# Altium schematic sheet 36V_Supply.SchDoc(36V_Supply)
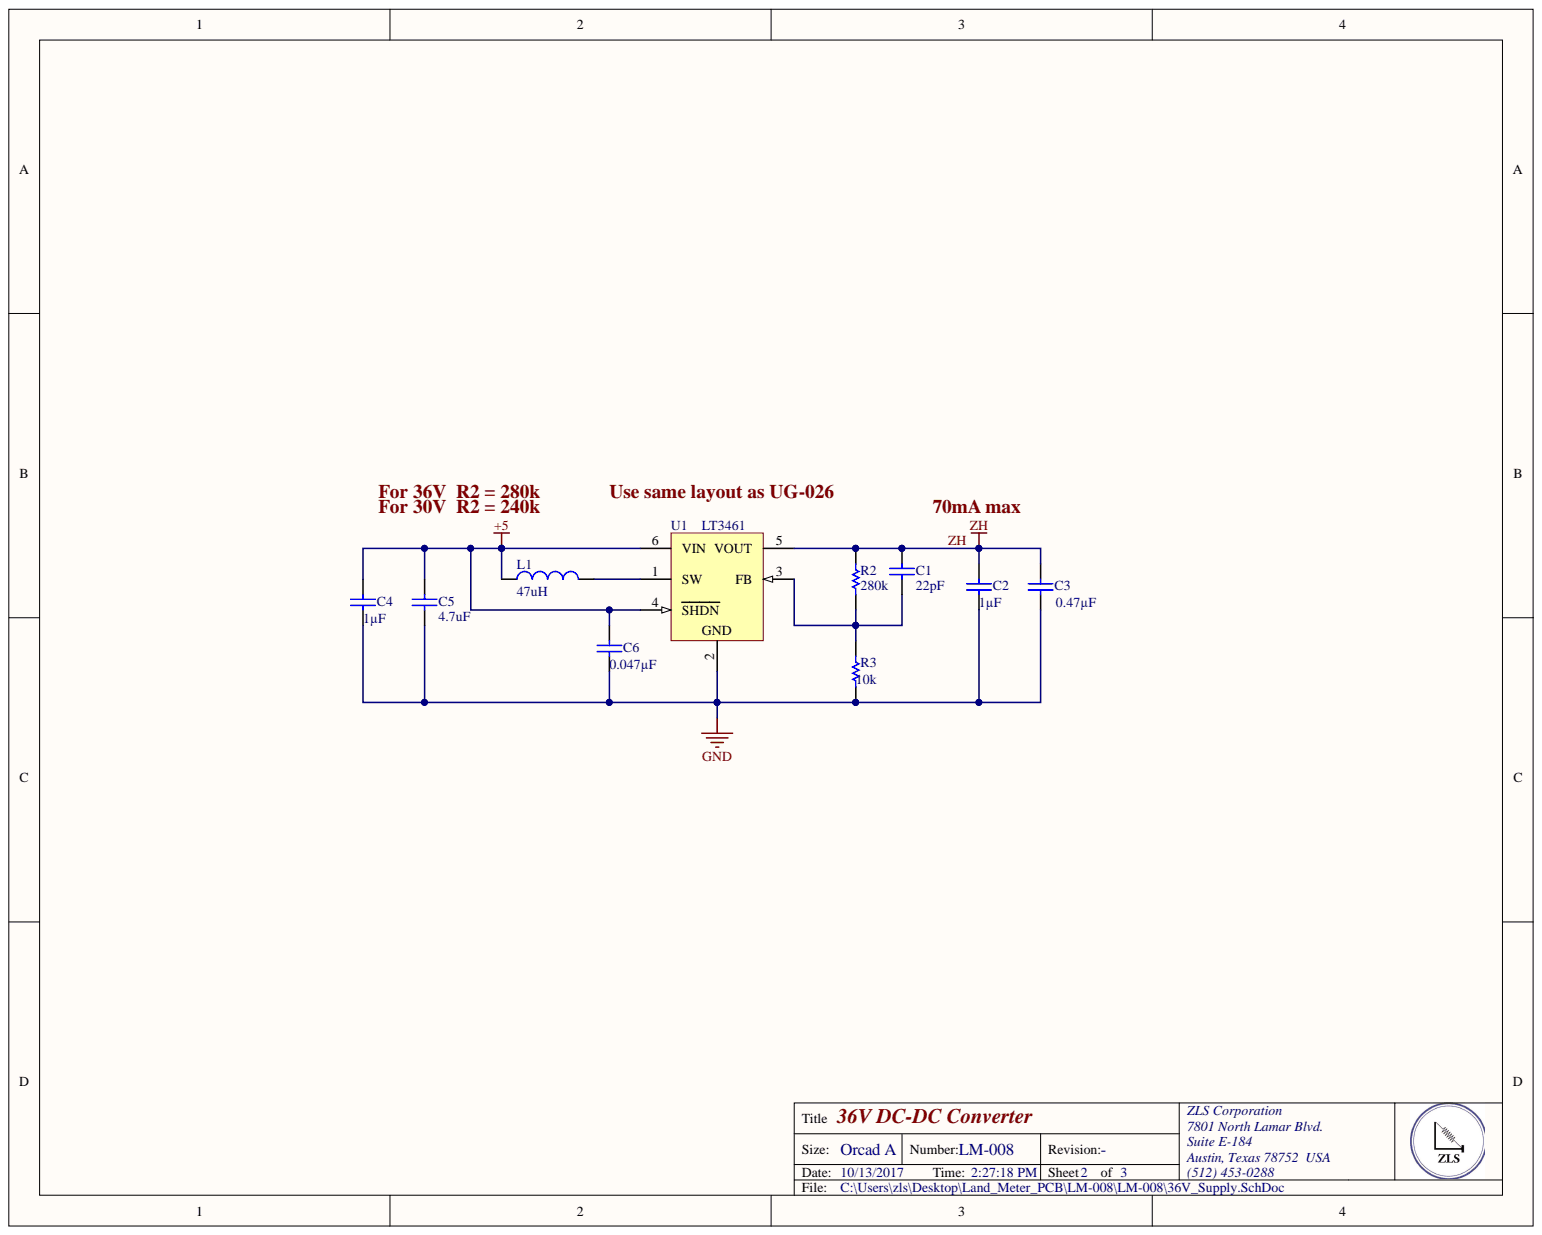
[diagram p0: 36V_Supply.SchDoc]

## Parts
C1  22pF  [CAPC1206(3216)170_L]
C2  Capacitance: 1µF  [CAPC1210(3225)100_N]  (hidden comment: CAP CER 1UF 50V X7R 1210)
C3  Capacitance: 0.47µF  [CAPC1206(3216)190_N]  (hidden comment: CAP CER 0.47UF 50V X7R 1206)
C4  Capacitance: 1µF  [CAPC0805(2012)145_N]  (hidden comment: CAP CER 1UF 16V X7R 0805)
C5  4.7uF  [CAPC0805(2012)145_M]
C6  Capacitance: 0.047µF  [CAPC0805(2012)145_N]  (hidden comment: CAP CER 0.047UF 16V X7R 0603)
L1  47uH  [C1210]
R2  Resistance (Ohms): 280k  [RESC0805(2012)_M]  (hidden comment: RES SMD 280K OHM 1% 1/8W 0805)
R3  Resistance (Ohms): 10k  [RESC0805(2012)_M]  (hidden comment: RES SMD 10K OHM 1% 1/8W 0805)
U1  LT3461  [LT-S6-6-TSOT-23_N]

## Nets
+5: C4.2 C5.2 C6.2 L1.1 U1.4(SHDN) U1.6(VIN)
GND: C2.1 C3.1 C4.1 C5.1 C6.1 R3.2 U1.2
ZH: C1.2 C2.2 C3.2 R2.1 U1.5(VOUT)
NetC1_1: C1.1 R2.2 R3.1 U1.3(FB)
NetL1_2: L1.2 U1.1(SW)

## Library data of the parts
C1 = CMP-1037-04573-1: CAP CER 22PF 50V C0G/NP0 1206; Manufacturer Part Number: C1206C220K5GACTU; Manufacturer: KEMET; Tolerance: ±10%; Temperature Coefficient: C0G, NP0; Voltage - Rated: 50V; Thickness (Max): 0.035" (0.88mm); Supplier Part Number 1: 399-8165-1-ND; Supplier 1: Digi-Key; Stock 1: 35613; Size / Dimension: 0.126" L x 0.063" W (3.20mm x 1.60mm); RoHS: RoHS Compliant; Ratings: -; Pricing 1: 1=0.18, 10=0.128, 100=0.06, 500=0.04208, 1000=0.03483 (USD); Part Status: Active; Packaging: Cut Tape (CT); Package / Case: 1206 (3216 Metric); Operating Temperature: -55°C ~ 125°C; Mounting Type: Surface Mount, MLCC; Library Name: Altium Content Vault; Lead Style: -; Lead Spacing: -; Height - Seated (Max): -; Features: Low ESL; Failure Rate: -; ComponentLink1URL: http://www.kemet.com/docfinder?Partnumber=C1206C220K2GACTU; ComponentLink1Description: http://www.kemet.com/docfinder?Partnumber=C1206C220K2GACTU; Component Kind: Standard; Category: Capacitors; Applications: General Purpose
C2 = CMP-1038-01123-1: Manufacturer Part Number: UMK325B7105KH-T; Manufacturer: Taiyo Yuden; Tolerance: ±10%; Voltage - Rated: 50V; Thickness (Max): 0.063" (1.60mm); Temperature Coefficient: X7R; Supplier Part Number 1: 587-1366-1-ND; Supplier 1: Digi-Key; Stock 1: 23864; Size / Dimension: 0.126" L x 0.098" W (3.20mm x 2.50mm); RoHS: RoHS Compliant; Ratings: -; Pricing 1: 1=0.36, 10=0.247, 100=0.1398, 500=0.0987, 1000=0.08637 (USD); Part Status: Active; Packaging: Cut Tape (CT); Package / Case: 1210 (3225 Metric); Operating Temperature: -55°C ~ 125°C; Mounting Type: Surface Mount, MLCC; Library Name: Altium Content Vault; Lead Style: -; Lead Spacing: -; Height - Seated (Max): -; Features: -; Description: CAP CER 1UF 50V X7R 1210; ComponentLink1URL: http://www.yuden.co.jp/productdata/catalog/en/mlcc_all_e.pdf; ComponentLink1Description: http://www.yuden.co.jp/productdata/catalog/en/mlcc_all_e.pdf; Component Kind: Standard; Category: Capacitors; Applications: General Purpose
C3 = CMP-1037-04570-1: Manufacturer Part Number: UMK316B7474KL-T; Manufacturer: Taiyo Yuden; Tolerance: ±10%; Voltage - Rated: 50V; Thickness (Max): 0.071" (1.80mm); Temperature Coefficient: X7R; Supplier Part Number 1: 587-1325-1-ND; Supplier 1: Digi-Key; Stock 1: 8658; Size / Dimension: 0.126" L x 0.063" W (3.20mm x 1.60mm); RoHS: RoHS Compliant; Ratings: -; Pricing 1: 1=0.3, 10=0.203, 100=0.115, 500=0.08114, 1000=0.071 (USD); Part Status: Active; Packaging: Cut Tape (CT); Package / Case: 1206 (3216 Metric); Operating Temperature: -55°C ~ 125°C; Mounting Type: Surface Mount, MLCC; Library Name: Altium Content Vault; Lead Style: -; Lead Spacing: -; Height - Seated (Max): -; Features: -; Description: CAP CER 0.47UF 50V X7R 1206; ComponentLink1URL: http://www.yuden.co.jp/productdata/catalog/en/mlcc_all_e.pdf; ComponentLink1Description: http://www.yuden.co.jp/productdata/catalog/en/mlcc_all_e.pdf; Component Kind: Standard; Category: Capacitors; Applications: General Purpose
C4 = CMP-1036-04795-1: Manufacturer Part Number: EMK212B7105KG-T; Manufacturer: Taiyo Yuden; Tolerance: ±10%; Voltage - Rated: 16V; Thickness (Max): 0.053" (1.35mm); Temperature Coefficient: X7R; Supplier Part Number 1: 587-1283-1-ND; Supplier 1: Digi-Key; Stock 1: 41495; Size / Dimension: 0.079" L x 0.049" W (2.00mm x 1.25mm); RoHS: RoHS Compliant; Ratings: -; Pricing 1: 1=0.13, 10=0.089, 100=0.042, 1000=0.02439 (USD); Part Status: Active; Packaging: Cut Tape (CT); Package / Case: 0805 (2012 Metric); Operating Temperature: -55°C ~ 125°C; Mounting Type: Surface Mount, MLCC; Library Name: Altium Content Vault; Lead Style: -; Lead Spacing: -; Height - Seated (Max): -; Features: -; Description: CAP CER 1UF 16V X7R 0805; ComponentLink1URL: http://ds.yuden.co.jp/TYCOMPAS/ut/detail.do?productNo=EMK212B7105KG-T&fileName=EMK212B7105KG-T_SS&mode=specSheetDownload; ComponentLink1Description: http://ds.yuden.co.jp/TYCOMPAS/ut/detail.do?productNo=EMK212B7105KG-T&fileName=EMK212B7105KG-T_SS&mode=specSheetDownload; Component Kind: Standard; Category: Capacitors; Applications: General Purpose
C5 = CMP-1036-04750-1: CAP CER 4.7UF 16V X7R 0805; Manufacturer Part Number: C0805C475K4RACTU; Manufacturer: KEMET; Tolerance: ±10%; Voltage - Rated: 16V; Thickness (Max): 0.055" (1.40mm); Temperature Coefficient: X7R; Supplier Part Number 1: 399-7415-1-ND; Supplier 1: Digi-Key; Stock 1: 88820; Size / Dimension: 0.079" L x 0.049" W (2.00mm x 1.25mm); RoHS: RoHS Compliant; Ratings: -; Pricing 1: 1=0.26, 10=0.176, 100=0.0894, 500=0.06118, 1000=0.05412 (USD); Part Status: Active; Packaging: Cut Tape (CT); Package / Case: 0805 (2012 Metric); Operating Temperature: -55°C ~ 125°C; Mounting Type: Surface Mount, MLCC; Library Name: Altium Content Vault; Lead Style: -; Lead Spacing: -; Height - Seated (Max): -; Features: Low ESL; Failure Rate: -; ComponentLink1URL: http://www.kemet.com/docfinder?Partnumber=C0805C475K4RACTU; ComponentLink1Description: http://www.kemet.com/docfinder?Partnumber=C0805C475K4RACTU; Component Kind: Standard; Category: Capacitors; Applications: General Purpose
C6 = CMP-1036-04795-1: Manufacturer Part Number: GRM188R71C473KA01D; Manufacturer: Murata Electronics North America; Tolerance: ±10%; Voltage - Rated: 16V; Thickness (Max): 0.035" (0.90mm); Temperature Coefficient: X7R; Supplier Part Number 1: 490-1529-6-ND; Supplier 1: Digi-Key; Stock 1: 26; Size / Dimension: 0.063" L x 0.031" W (1.60mm x 0.80mm); RoHS: RoHS Compliant; Ratings: -; Pricing 1: 1=0.1, 10=0.046, 100=0.0206, 500=0.0147, 1000=0.01155 (USD); Part Status: Active; Packaging: Digi-Reel®; Package / Case: 0603 (1608 Metric); Operating Temperature: -55°C ~ 125°C; Mounting Type: Surface Mount, MLCC; Library Name: Altium Content Vault; Lead Style: -; Lead Spacing: -; Height - Seated (Max): -; Features: -; Description: CAP CER 0.047UF 16V X7R 0603; ComponentLink1URL: http://www.murata.com/~/media/webrenewal/support/library/catalog/products/capacitor/mlcc/c02e.ashx; ComponentLink1Description: http://www.murata.com/~/media/webrenewal/support/library/catalog/products/capacitor/mlcc/c02e.ashx; Component Kind: Standard; Category: Capacitors; Applications: General Purpose
L1 = Inductor: FIXED IND 47UH 170MA 1.3 OHM SMD; Manufacturer Part Number: LQH32CN470K23L; Manufacturer: Murata Electronics North America; Type: Wirewound; Tolerance: ±10%; Shielding: Unshielded; Material - Core: Ferrite; DC Resistance (DCR): 1.69 Ohm Max; Supplier Part Number 1: 490-2497-1-ND; Supplier Device Package: 1210; Supplier 1: Digi-Key; Stock 1: 1058; Size / Dimension: 0.126" L x 0.098" W (3.20mm x 2.50mm); Simulation: INDUCTOR; Signal Integrity: Inductor; RoHS: RoHS Compliant; Ratings: -; Q @ Freq: -; Pricing 1: 1=0.33, 10=0.297, 100=0.2043, 500=0.17458, 1000=0.13372 (USD); Part Status: Active; Packaging: Cut Tape (CT); Package / Case: Nonstandard; Operating Temperature: -40°C ~ 85°C; Mounting Type: Surface Mount; Library Name: Miscellaneous Devices.IntLib; Height - Seated (Max): 0.067" (1.70mm); ComponentLink1URL: http://search.murata.co.jp/Ceramy/image/img/P02/JELF243A-0039.pdf; ComponentLink1Description: http://search.murata.co.jp/Ceramy/image/img/P02/JELF243A-0039.pdf; Component Kind: Standard; Category: Inductors, Coils, Chokes
R2 = CMP-1013-00017-1: Manufacturer Part Number: CRCW0805280KFKEA; Manufacturer: Vishay Dale; Temperature Coefficient: ±100ppm/°C; Power (Watts): 0.125W, 1/8W; Tolerance: ±1%; Supplier Part Number 1: 541-280KCTR-ND; Supplier Device Package: 0805; Supplier 1: Digi-Key; Stock 1: 10000; Size / Dimension: 0.079" L x 0.049" W (2.00mm x 1.25mm); RoHS: RoHS Compliant; Pricing 1: 5000=0.00546, 10000=0.00474, 25000=0.00417, 50000=0.00382, 125000=0.00375 (USD); Part Status: Active; Packaging: Tape & Reel (TR); Package / Case: 0805 (2012 Metric); Operating Temperature: -55°C ~ 155°C; Library Name: Altium Content Vault; Height - Seated (Max): 0.020" (0.50mm); Features: Automotive AEC-Q200; Failure Rate: -; Description: RES SMD 280K OHM 1% 1/8W 0805; Composition: Thick Film; ComponentLink1URL: http://www.vishay.com/docs/20035/dcrcwe3.pdf; ComponentLink1Description: http://www.vishay.com/docs/20035/dcrcwe3.pdf; Component Kind: Standard; Category: Resistors
R3 = CMP-1013-00017-1: Manufacturer Part Number: RC0805FR-0710KL; Manufacturer: Yageo; Temperature Coefficient: ±100ppm/°C; Power (Watts): 0.125W, 1/8W; Tolerance: ±1%; Supplier Part Number 1: 311-10.0KCRCT-ND; Supplier Device Package: 0805; Supplier 1: Digi-Key; Stock 1: 13336570; Size / Dimension: 0.079" L x 0.049" W (2.00mm x 1.25mm); RoHS: RoHS Compliant; Pricing 1: 1=0.1, 10=0.022, 100=0.0088, 1000=0.00396, 2500=0.00344 (USD); Part Status: Active; Packaging: Cut Tape (CT); Package / Case: 0805 (2012 Metric); Operating Temperature: -55°C ~ 155°C; Library Name: Altium Content Vault; Height - Seated (Max): 0.024" (0.60mm); Features: Moisture Resistant; Failure Rate: -; Description: RES SMD 10K OHM 1% 1/8W 0805; Composition: Thick Film; ComponentLink1URL: http://www.yageo.com/documents/recent/PYu-RC_Group_51_RoHS_L_7.pdf; ComponentLink1Description: http://www.yageo.com/documents/recent/PYu-RC_Group_51_RoHS_L_7.pdf; Component Kind: Standard; Category: Resistors
U1 = CMP-0491-01698-1: IC REG BOOST ADJ 0.3A TSOT23-6; Manufacturer Part Number 1: LT3461AES6#TRMPBF; Manufacturer 1: Linear Technology; Voltage - Output (Min/Fixed): 1.255V; Voltage - Output (Max): 38V; Voltage - Input (Min): 2.5V; Voltage - Input (Max): 16V; Synchronous Rectifier: No; Output Type: Adjustable; Output Configuration: Positive; Number of Outputs: 1; Function: Step-Up; Frequency - Switching: 3MHz; Current - Output: 300mA (Switch); Category 1: Integrated Circuits (ICs); Topology: Boost; Supplier Part Number 1: LT3461AES6#TRMPBFCT-ND; Supplier Device Package: TSOT-23-6; Supplier 1: Digi-Key; Stock 1: 1325; RoHS 1: RoHS Compliant; Pricing 1: 1=3.94, 25=3.52, 100=2.4, 250=2.2 (USD); Part Status: Active; Packaging: Cut Tape (CT); Package / Case: SOT-23-6 Thin, TSOT-23-6; Operating Temperature: 0°C ~ 70°C (TA); Mounting Type: Surface Mount; Library Name: Altium Content Vault; Description 1: IC REG BOOST ADJ 0.3A TSOT23-6; ComponentLink1URL: http://www.linear.com/docs/3469; ComponentLink1Description: http://www.linear.com/docs/3469; Component Kind: Standard
